# Altium schematic sheet Buttons, LEDs and Connectors.SchDoc("Buttons, LEDs and Connectors")
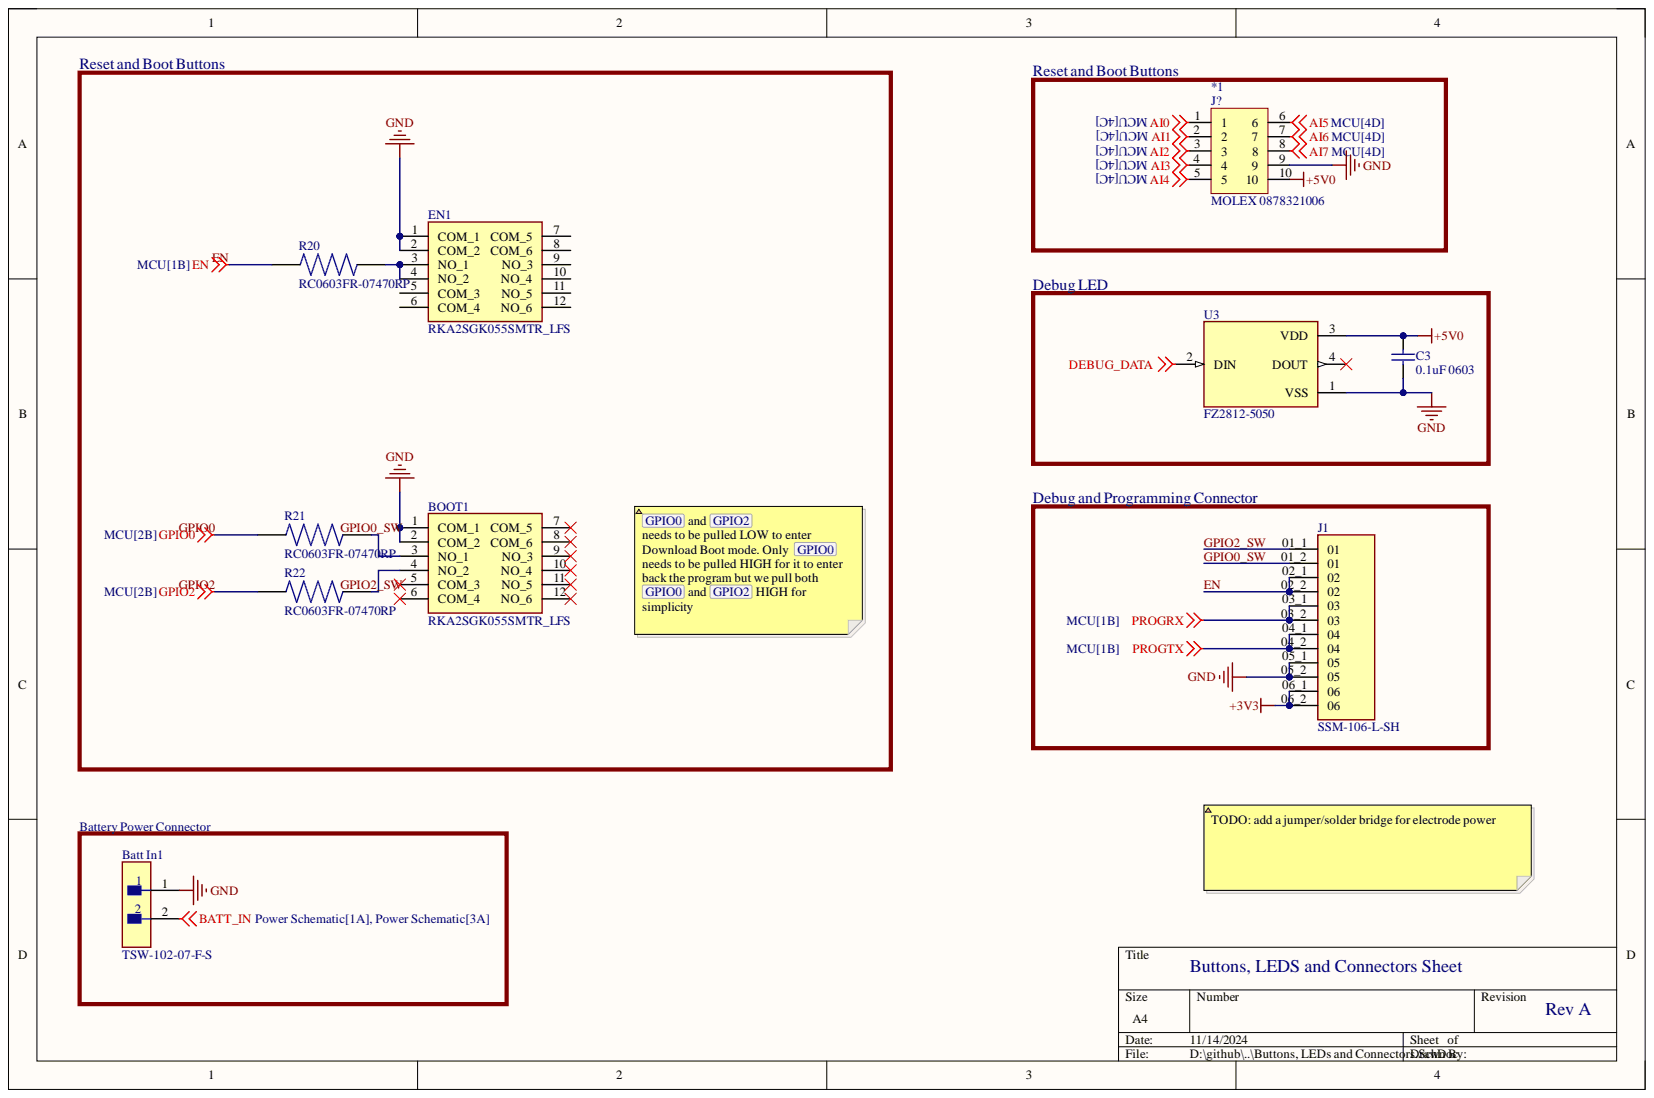
[diagram p0: Buttons, LEDs and Connectors.SchDoc]

## Parts
*1  MOLEX 0878321006  [MOLEX 0878321006]
Batt_In1  TSW-102-07-F-S  [FP-TSW-102-07-F-S-MFG]
BOOT1  RKA2SGK055SMTR_LFS  [RKA2SGK055SMTRLFS]
C3  0.1uF 0603  [CAPC1608X90X40LL10T20]
EN1  RKA2SGK055SMTR_LFS  [RKA2SGK055SMTRLFS]
J1  SSM-106-L-SH  [SAMTEC_SSM-106-L-SH]
R20  RC0603FR-07470RP  [RESC1608X55N]
R21  RC0603FR-07470RP  [RESC1608X55N]
R22  RC0603FR-07470RP  [RESC1608X55N]
U3  FZ2812-5050  [LED_FZ2812-5050]

## Nets
+3V3: J1.06_1 J1.06_2
+5V0: *1.10 C3.2 U3.3(VDD)
AI0: *1.1
AI1: *1.2
AI2: *1.3
AI3: *1.4
AI4: *1.5
AI5: *1.6
AI6: *1.7
AI7: *1.8
BATT_IN: Batt_In1.2
DEBUG_DATA: U3.2(DIN)
GND: *1.9 Batt_In1.1 BOOT1.1(COM_1) BOOT1.2(COM_2) C3.1 EN1.1(COM_1) EN1.2(COM_2) J1.05_1 J1.05_2 U3.1(VSS)
PROGRX: J1.03_1 J1.03_2
PROGTX: J1.04_1 J1.04_2
EN: J1.02_1 J1.02_2 R20.2
GPIO0: R21.2
GPIO0_SW: BOOT1.3(NO_1) J1.01_2 R21.1
GPIO2: R22.2
GPIO2_SW: BOOT1.4(NO_2) J1.01_1 R22.1
NetEN1_3: EN1.3(NO_1) EN1.4(NO_2) R20.1

Not connected: BOOT1 5(COM_3) 6(COM_4) 7(COM_5) 8(COM_6) 9(NO_3) 10(NO_4) 11(NO_5) 12(NO_6); EN1 5(COM_3) 6(COM_4) 7(COM_5) 8(COM_6) 9(NO_3) 10(NO_4) 11(NO_5) 12(NO_6); U3 4(DOUT)

## Library data of the parts
Batt_In1 = CMP-02766-001458-1: CONN HEADER VERT 2POS 2.54MM; Gender: Male; Max Current Rating: 7.6A; Max Voltage Rating (AC): 550V; Number of Contacts: 2; Color: Black; Component Kind: Standard; Connector Type: Header; Contact Finish - Mating: GOLD; Contact Material: Bronze; Contact Mating Length: 0.23inch; Contact Plating: Gold; Contact Shape: Square; Contact Type: Male Pin; Depth: 2.54mm; Fastening Type: Pull; Flammability Rating: UL94 V-0; Housing Color: Black; Housing Material: Polyester; Insulation Color: Black; Insulation Material: PBT; Length: 5.08mm; Library Name: Altium Content Vault; Max Operating Temperature: 125°C; Min Operating Temperature: -55°C; Mount: Through Hole; Number of Positions: 2; Number of Rows: 1; Orientation: Straight; Package Quantity: 1; Packaging: Bulk; Pitch: 2.54mm; Radiation Hardening: No; REACH SVHC: No SVHC; RoHS Compliant: Yes; Series: TSW; Termination: Solder
BOOT1 EN1 = RKA2SGK055SMTR_LFS: Switch; Manufacturer_Name: C & K COMPONENTS; Manufacturer_Part_Number: RKA2SGK055SMTR LFS; Arrow Part Number: RKA2SGK055SMTR LFS; Arrow Price/Stock: https://www.arrow.com/en/products/rka2sgk055smtr-lfs/ck?region=europe; Component Kind: Standard; Datasheet Link: https://www.ckswitches.com/media/3130/ck_rk_datasheet.pdf; Height: 0.55mm; Mouser Part Number: 611-RKA2SGK055SMTRLF; Mouser Price/Stock: https://www.mouser.co.uk/ProductDetail/CK/RKA2SGK055SMTR-LFS?qs=iLbezkQI%252BsiGAt%252Bzcl2zSQ%3D%3D
C3 = CMP-1035-02594-2: Chip Capacitor, 100 nF, - 20% to + 80%, 50 V, -30 to 85 degC, 0603 (1608 Metric), RoHS, Tape and Reel; Manufacturer: Yageo; Capacitance: 0.1µF; Featured Product: CommodityMultilayerCeramicCapacitors–CCSeries; Kits: CC060300000000000-ND-MLCC0603SAMPLEKIT; Online Catalog: CCSeries; Other Names: 311-1343-1; Product Training Modules: MultilayerCeramicCapacitors; Temperature Coefficient: Y5V(F); Tolerance: -20%,+80%; Voltage - Rated: 50V; Applications: GeneralPurpose; Category: Capacitors; Component Kind: Standard; Datasheet URL: https://datasheet.ciiva.com/17434/upy-gphc-y5v-6-3v-to-50v-5-17434523.pdf; Datasheet Version: Rev. 5, 07/2010; Family: CeramicCapacitors; Features: -; Height - Seated (Max): -; Lead Spacing: -; Lead Style: -; Library Name: Altium Content Vault; Manufacturer URL: http://www.yageo.com/; Mounting Technology: SurfaceMount; Mounting Type: SurfaceMount,MLCC; Operating Temperature: -30°C~85°C; Package / Case: 0603(1608Metric); Package Description: 2-Pin Surface Mount Device, Body 1.6 x 0.8 mm; Package Reference: 0603; Packaging: CutTape(CT); Ratings: -; Series: CC; Size / Dimension: 0.063"Lx0.031"W(1.60mmx0.80mm); Standard Package: 1; Thickness (Max): 0.035"(0.90mm)
J1 = SSM-106-L-SH: MF: {'manufacturer': u'Samtec'}; Availability: Unavailable; Component Kind: Standard; MP: SSM-106-L-SH; Package: None; Price: None
R20-R22 = RC0603FR-07470RP: RES SMD 470 OHM 1% 1/10W 0603; MF: {'manufacturer': u'Yageo Corporation'}; Availability: Unavailable; Component Kind: Standard; MP: RC0603FR-07470RP; Package: None; Price: None
U3 = FZ2812-5050: MF: {'manufacturer': u'Feztek'}; Availability: Unavailable; Component Kind: Standard; MP: FZ2812-5050; Package: None; Price: None
*1 = MOLEX 0878321006: Component Kind: Standard; Library Name: Custom.SchLib
